# Altium schematic sheet Schematic - V2.SchDoc
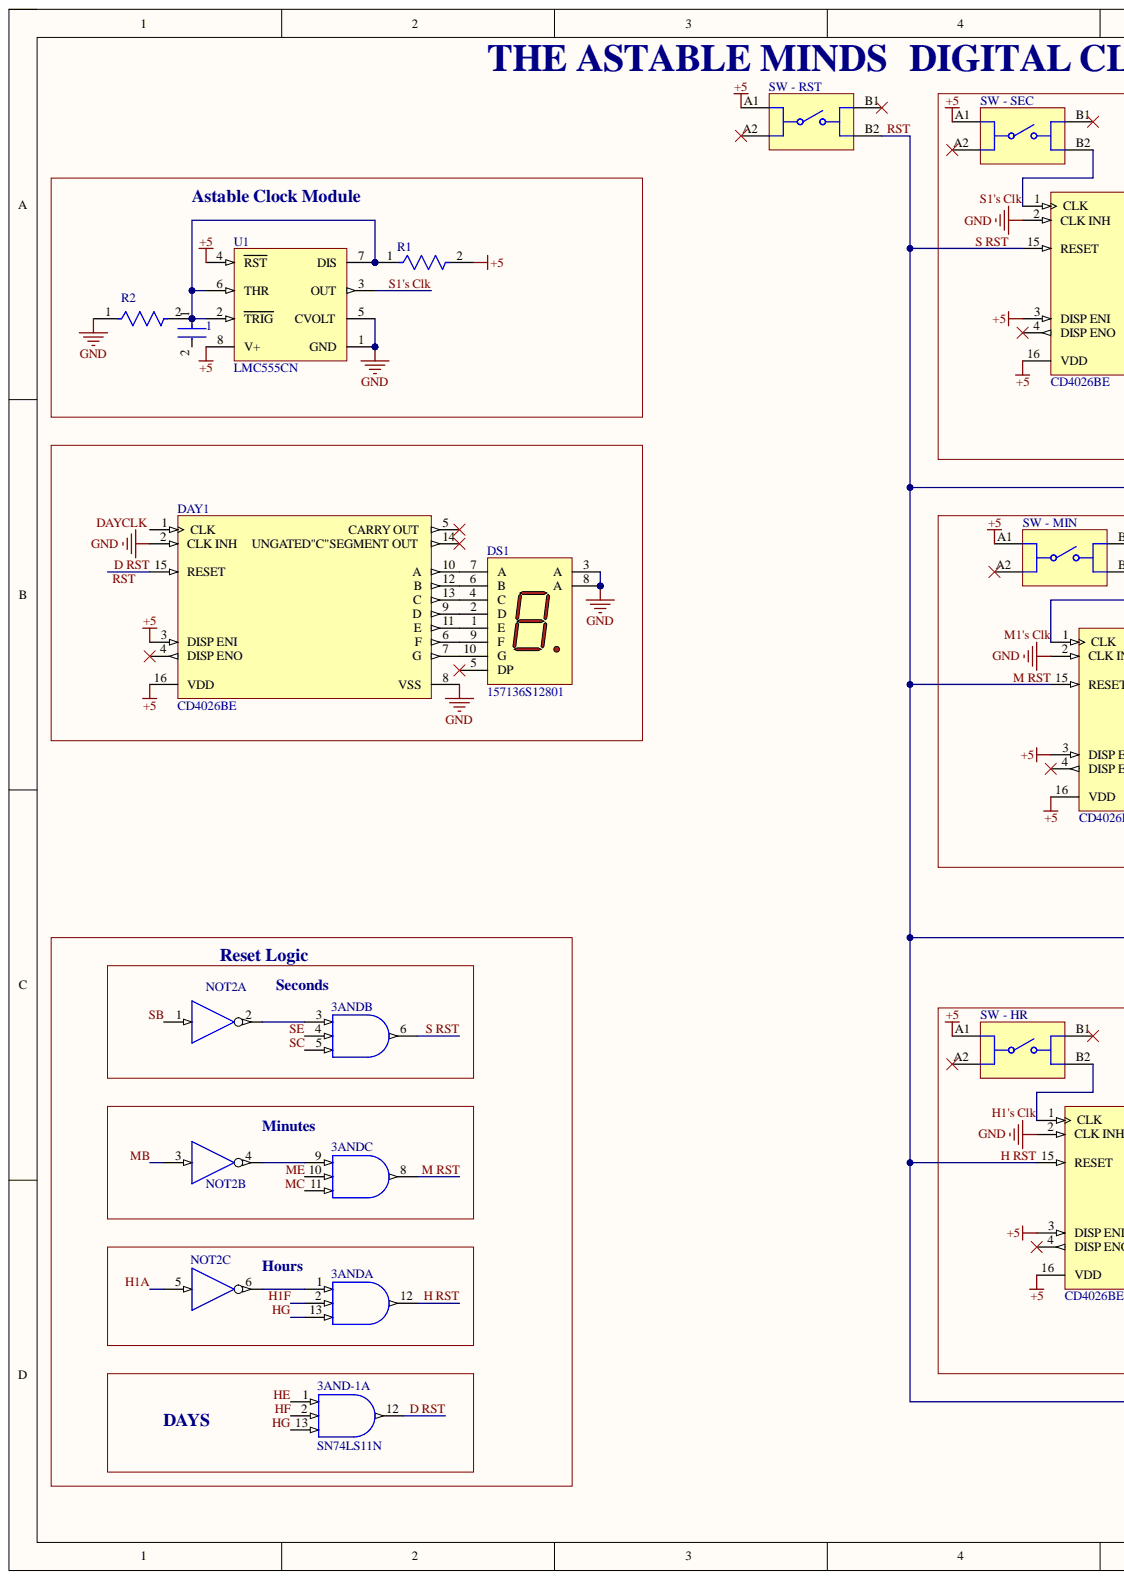
[diagram p0: Schematic - V2.SchDoc, left]
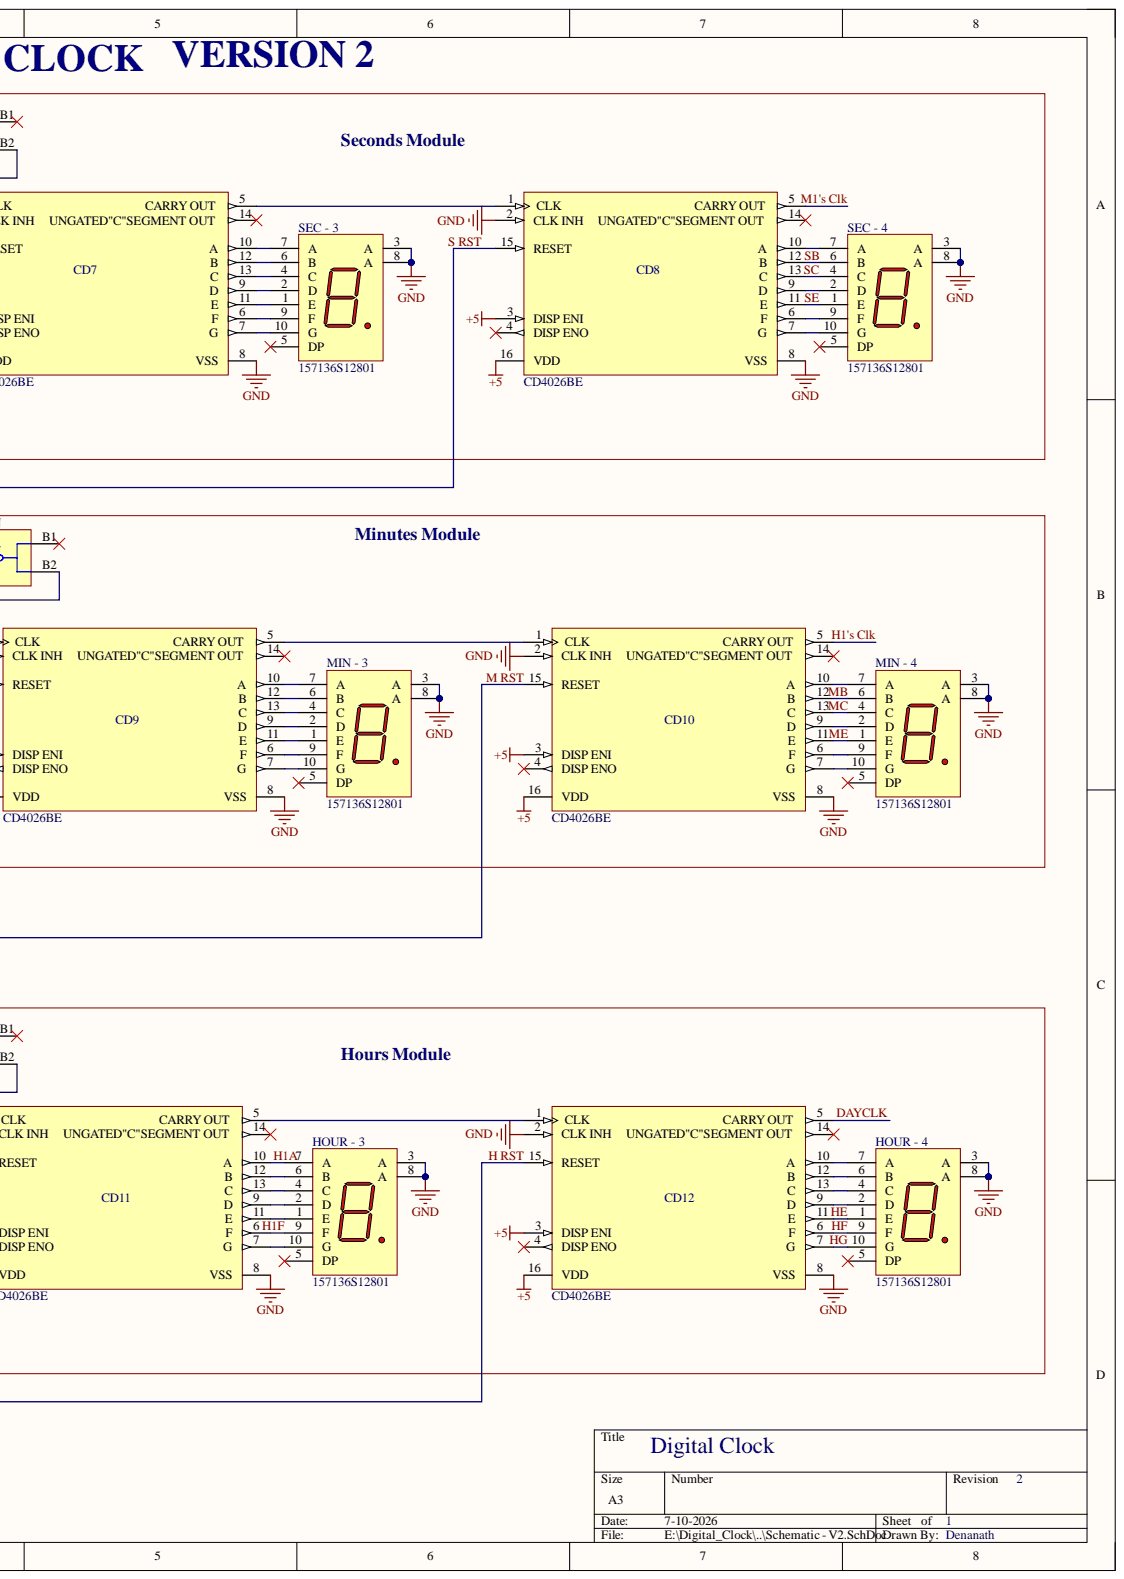
[diagram p1: Schematic - V2.SchDoc, right]

## Parts
1  VY1472M63Y5UQ6TV0  [FP-VY1472M63Y5UQ6TV0-MFG]  (value not drawn)
3AND  SN74LS11N  [N0014A]  (parts A,B,C)
3AND-1  SN74LS11N  [N0014A]  (part A)
CD7  CD4026BE  [N0016A]
CD8  CD4026BE  [N0016A]
CD9  CD4026BE  [N0016A]
CD10  CD4026BE  [N0016A]
CD11  CD4026BE  [N0016A]
CD12  CD4026BE  [N0016A]
DAY1  CD4026BE  [N0016A]
DS1  157136S12801  [WL-T7DS_L17.5W12.4H7]
HOUR_-_3  157136S12801  [WL-T7DS_L17.5W12.4H7]
HOUR_-_4  157136S12801  [WL-T7DS_L17.5W12.4H7]
MIN_-_3  157136S12801  [WL-T7DS_L17.5W12.4H7]
MIN_-_4  157136S12801  [WL-T7DS_L17.5W12.4H7]
NOT2  SN74HCU04N  [N0014A]  (value not drawn)  (parts A,B,C)
R1  VR37000001204JA100  [FP-VR37-MFG]  (value not drawn)
R2  VR37000001204JA100  [FP-VR37-MFG]  (value not drawn)
SEC_-_3  157136S12801  [WL-T7DS_L17.5W12.4H7]
SEC_-_4  157136S12801  [WL-T7DS_L17.5W12.4H7]
SW_-_HR  430186050716  [430186050716]  (value not drawn)
SW_-_MIN  430186050716  [430186050716]  (value not drawn)
SW_-_RST  430186050716  [430186050716]  (value not drawn)
SW_-_SEC  430186050716  [430186050716]  (value not drawn)
U1  LMC555CN  [P0008A]

## Nets
+5: CD7.3(DISP_ENI) CD7.16(VDD) CD8.3(DISP_ENI) CD8.16(VDD) CD9.3(DISP_ENI) CD9.16(VDD) CD10.3(DISP_ENI) CD10.16(VDD) CD11.3(DISP_ENI) CD11.16(VDD) CD12.3(DISP_ENI) CD12.16(VDD) DAY1.3(DISP_ENI) DAY1.16(VDD) R1.2 SW_-_HR.A1 SW_-_MIN.A1 SW_-_RST.A1 SW_-_SEC.A1 U1.4(RST) U1.8(V+)
GND: CD7.2(CLK_INH) CD7.8(VSS) CD8.2(CLK_INH) CD8.8(VSS) CD9.2(CLK_INH) CD9.8(VSS) CD10.2(CLK_INH) CD10.8(VSS) CD11.2(CLK_INH) CD11.8(VSS) CD12.2(CLK_INH) CD12.8(VSS) DAY1.2(CLK_INH) DAY1.8(VSS) DS1.3(A) DS1.8(A) HOUR_-_3.3(A) HOUR_-_3.8(A) HOUR_-_4.3(A) HOUR_-_4.8(A) MIN_-_3.3(A) MIN_-_3.8(A) MIN_-_4.3(A) MIN_-_4.8(A) R2.1 SEC_-_3.3(A) SEC_-_3.8(A) SEC_-_4.3(A) SEC_-_4.8(A) U1.1(GND) U1.5(CVOLT)
D RST: 3AND-1.12 DAY1.15(RESET)
DAYCLK: CD12.5(CARRY_OUT) DAY1.1(CLK)
H1's Clk: CD10.5(CARRY_OUT) CD11.1(CLK) SW_-_HR.B2
H1A: NOT2.5
H1F: 3AND.2 CD11.6(F) HOUR_-_3.9(F)
H RST: 3AND.6 3AND.8 3AND.12 CD7.15(RESET) CD8.15(RESET) CD9.15(RESET) CD10.15(RESET) CD11.15(RESET) CD12.15(RESET) SW_-_RST.B2
HE: 3AND-1.1 CD12.11(E) HOUR_-_4.1(E)
HF: 3AND-1.2 CD12.6(F) HOUR_-_4.9(F)
HG: 3AND.13 3AND-1.13 CD12.7(G) HOUR_-_4.10(G)
M1's Clk: CD8.5(CARRY_OUT) CD9.1(CLK) SW_-_MIN.B2
MB: CD10.12(B) MIN_-_4.6(B) NOT2.3
MC: 3AND.11 CD10.13(C) MIN_-_4.4(C)
ME: 3AND.10 CD10.11(E) MIN_-_4.1(E)
S1's Clk: CD7.1(CLK) SW_-_SEC.B2 U1.3(OUT)
SB: CD8.12(B) NOT2.1 SEC_-_4.6(B)
SC: 3AND.5 CD8.13(C) SEC_-_4.4(C)
SE: 3AND.4 CD8.11(E) SEC_-_4.1(E)
Net1_1: 1.1 R1.1 R2.2 U1.2(TRIG) U1.6(THR) U1.7(DIS)
Net1_2: 1.2
Net3AND_1: 3AND.1 NOT2.6
Net3AND_3: 3AND.3 NOT2.2
Net3AND_9: 3AND.9 NOT2.4
NetCD7_5: CD7.5(CARRY_OUT) CD8.1(CLK)
NetCD7_6: CD7.6(F) SEC_-_3.9(F)
NetCD7_7: CD7.7(G) SEC_-_3.10(G)
NetCD7_9: CD7.9(D) SEC_-_3.2(D)
NetCD7_10: CD7.10(A) SEC_-_3.7(A)
NetCD7_11: CD7.11(E) SEC_-_3.1(E)
NetCD7_12: CD7.12(B) SEC_-_3.6(B)
NetCD7_13: CD7.13(C) SEC_-_3.4(C)
NetCD8_6: CD8.6(F) SEC_-_4.9(F)
NetCD8_7: CD8.7(G) SEC_-_4.10(G)
NetCD8_9: CD8.9(D) SEC_-_4.2(D)
NetCD8_10: CD8.10(A) SEC_-_4.7(A)
NetCD9_5: CD9.5(CARRY_OUT) CD10.1(CLK)
NetCD9_6: CD9.6(F) MIN_-_3.9(F)
NetCD9_7: CD9.7(G) MIN_-_3.10(G)
NetCD9_9: CD9.9(D) MIN_-_3.2(D)
NetCD9_10: CD9.10(A) MIN_-_3.7(A)
NetCD9_11: CD9.11(E) MIN_-_3.1(E)
NetCD9_12: CD9.12(B) MIN_-_3.6(B)
NetCD9_13: CD9.13(C) MIN_-_3.4(C)
NetCD10_6: CD10.6(F) MIN_-_4.9(F)
NetCD10_7: CD10.7(G) MIN_-_4.10(G)
NetCD10_9: CD10.9(D) MIN_-_4.2(D)
NetCD10_10: CD10.10(A) MIN_-_4.7(A)
NetCD11_5: CD11.5(CARRY_OUT) CD12.1(CLK)
NetCD11_7: CD11.7(G) HOUR_-_3.10(G)
NetCD11_9: CD11.9(D) HOUR_-_3.2(D)
NetCD11_10: CD11.10(A) HOUR_-_3.7(A)
NetCD11_11: CD11.11(E) HOUR_-_3.1(E)
NetCD11_12: CD11.12(B) HOUR_-_3.6(B)
NetCD11_13: CD11.13(C) HOUR_-_3.4(C)
NetCD12_9: CD12.9(D) HOUR_-_4.2(D)
NetCD12_10: CD12.10(A) HOUR_-_4.7(A)
NetCD12_12: CD12.12(B) HOUR_-_4.6(B)
NetCD12_13: CD12.13(C) HOUR_-_4.4(C)
NetDAY1_6: DAY1.6(F) DS1.9(F)
NetDAY1_7: DAY1.7(G) DS1.10(G)
NetDAY1_9: DAY1.9(D) DS1.2(D)
NetDAY1_10: DAY1.10(A) DS1.7(A)
NetDAY1_11: DAY1.11(E) DS1.1(E)
NetDAY1_12: DAY1.12(B) DS1.6(B)
NetDAY1_13: DAY1.13(C) DS1.4(C)

Not connected: CD7 4(DISP_ENO) 14(UNGATED"C"SEGMENT_OUT); CD8 4(DISP_ENO) 14(UNGATED"C"SEGMENT_OUT); CD9 4(DISP_ENO) 14(UNGATED"C"SEGMENT_OUT); CD10 4(DISP_ENO) 14(UNGATED"C"SEGMENT_OUT); CD11 4(DISP_ENO) 14(UNGATED"C"SEGMENT_OUT); CD12 4(DISP_ENO) 14(UNGATED"C"SEGMENT_OUT); DAY1 4(DISP_ENO) 5(CARRY_OUT) 14(UNGATED"C"SEGMENT_OUT); DS1 5(DP); HOUR_-_3 5(DP); HOUR_-_4 5(DP); MIN_-_3 5(DP); MIN_-_4 5(DP); SEC_-_3 5(DP); SEC_-_4 5(DP); SW_-_HR A2 B1; SW_-_MIN A2 B1; SW_-_RST A2 B1; SW_-_SEC A2 B1

## Library data of the parts
1 = CMP-02417-000050-1: CAP CER 4700PF 760VAC Y5U RADIAL; Capacitance: 4.7nF; Lead Diameter: 0.6mm; Lead/Base Style: Kinked; Tolerance: 20%; Voltage: 500V; Case/Package: Radial; Component Kind: Standard; Construction: RADIAL; Contact Plating: Tin; Depth: 5mm; Diameter: 16mm; Dielectric: Y5U; Height: 19mm; Height - Seated (Max): 0.787inch; Lead Pitch: 10mm; Library Name: Altium Content Vault; Max Operating Temperature: 125°C; Min Operating Temperature: -40°C; Mount: Through Hole; Package Quantity: 500; Packaging: Tape and Reel; Radiation Hardening: No; Resistor Type: Ceramic; RoHS Compliant: Yes; Series: VY1; Termination: Radial; Thickness: 5mm; Voltage Rating (AC): 500V; Voltage Rating (DC): 500V
3AND 3AND-1 = CMP-0777-00254-3: Triple 3-input positive-AND gates, N0014A, TUBE; Manufacturer: Texas Instruments; Bits(#): 3; F @ Nom Voltage(Max)(Mhz): 35; ICC @ Nom Voltage(Max)(mA): 0.0036; Input Type: TTL; Output Drive (IOL/IOH)(Max)(mA): 0/8; Rating: Catalog; Schmitt Trigger: No; Technology Family: LS; tpd @ Nom Voltage(Max)(ns): 15; VCC(Max)(V): 5.25; VCC(Min)(V): 4.75; Voltage(Nom)(V): 5; Component Kind: Standard; DatasheetVersion: SDLS131; Generic Part Number: SN74LS11; Library Name: Altium Content Vault; Operating Temperature Range(C): 0 to 70; Output Type: TTL; PackageReference: N0014A
CD7-CD12 DAY1 = CMP-1623-00561-2: CMOS Decade Counter/Divider with Decoded 7-Segment Display Outputs and Display Enable, N0016A, TUBE; Manufacturer: Texas Instruments; Bits(#): 7; F @ Nom Voltage(Max)(Mhz): 8; ICC @ Nom Voltage(Max)(mA): 0.03; Inputs: 1; Output Drive (IOL/IOH)(Max)(mA): 1.5/-1.5; Rating: Catalog; Schmitt Trigger: No; Technology Family: CD4000; tpd @ Nom Voltage(Max)(ns): 250; VCC(Max)(V): 18; VCC(Min)(V): 3; Voltage(Nom)(V): 5,10,15; Code_JEDEC: MS-001; Component Kind: Standard; DatasheetVersion: SCHS031B; Function: Counter; Generic Part Number: CD4026B; Input Type: CMOS; Library Name: Altium Content Vault; Operating Temperature Range(C): -55 to 125; Output Type: CMOS; PackageReference: N0016A; Type: Decade
DS1 HOUR_-_3 HOUR_-_4 MIN_-_3 MIN_-_4 SEC_-_3 SEC_-_4 = CMP-03913-119893999-3: 7-Seg Display, Comm Anode, Super Red Rohs Compliant: Yes; Dominant Wavelength: 635nm; Forward Current: 100mA; Forward Voltage: 2V; Luminous Intensity: 20mcd; Max Reverse Voltage (DC): 5V; Component Kind: Standard; Height: 7mm; Length: 17.5mm; Library Name: Altium Content Vault; Max Operating Temperature: 85°C; Min Operating Temperature: -40°C; RoHS: Compliant; Width: 12.4mm
NOT2 = CMP-1615-00910-2: Hex Inverters, N0014A, TUBE; Manufacturer: Texas Instruments; Bits(#): 6; F @ Nom Voltage(Max)(Mhz): 20; ICC @ Nom Voltage(Max)(mA): 0.02; Input Type: CMOS; Output Drive (IOL/IOH)(Max)(mA): -4/4; Rating: Catalog; Schmitt Trigger: No; Technology Family: HC; VCC(Min)(V): 2; Voltage(Nom)(V): 5; Code_JEDEC: MS-001; Component Kind: Standard; DatasheetVersion: SCLS079E; Generic Part Number: SN74HCU04; Library Name: Altium Content Vault; Operating Temperature Range(C): -40 to 85; Output Type: CMOS; PackageReference: N0014A; tpd @ Nom Voltage(Max)(ns): 20; VCC(Max)(V): 6
R1 R2 = CMP-02408-000054-1: RES 1.2M OHM 5% 1/2W AXIAL; Power Rating: 500mW; Resistance: 1.2MR; Temperature Coefficient: 200ppm/°C; Voltage Rating: 3.5kV; Case/Package: Conformal; Component Kind: Standard; Construction: Cylindrical; Contact Plating: Tin; Diameter: 4mm; Length: 10mm; Library Name: Altium Content Vault; Max Operating Temperature: 155°C; Military Standard: Not; Min Operating Temperature: -55°C; Mount: Through Hole; Packaging: Ammo Pack; Radiation Hardening: No; Reach SVHC Compliant: Yes; Resistance Tolerance: 5%; Resistor Type: Metal Film; Termination: Axial; Tolerance: 5%; Voltage Rating (DC): 3.5kV
SW_-_HR SW_-_MIN SW_-_RST SW_-_SEC = CMP-1453-00012-5: Switch Tactile Spst-no 0.05A 12V; Actuator Material: Liquid Crystal Polymer, Polymer; Contact Current Rating: 50mA; Illumination Type: Illuminated, Non-Illuminated; Insulation Resistance: 100MΩ; Mechanical Life: 1Mcycles; Switch Function: Off-Mom; Switch Travel: 250µm; Throw Configuration: SPST; Color: Black; Component Kind: Standard; Contact Material: Steel; Contact Plating: Silver; Current Rating: 50mA; Diameter: 3.5mm; Height: 5mm; Length: 6.2mm; Library Name: Altium Content Vault; Max Operating Temperature: 85°C; Min Operating Temperature: -40°C; Mount: Through Hole; Packaging: Bulk; REACH SVHC: No; RoHS: Compliant; Termination: PC Pin; Voltage Rating: 12V; Width: 6.2mm
U1 = CMP-0056-00035-3: CMOS Timer, 8-pin MDIP; Manufacturer: Texas Instruments; CompType: Analog IC; PackageTopMarking: NSUZXYTTE#*LMC*555CN; PowerWise: N; Code_JEDEC: MS-001-BA; Component Kind: Standard; ComponentLink1Description: Datasheet URL; ComponentLink1URL: http://www.ti.com/general/docs/lit/getliterature.tsp?genericPartNumber=LMC555&fileType=pdf; Library Name: Altium Content Vault; PackageDescription: MDIP, .365 x .250 x .130in, 8 Lead, .100in Pitch; PackageReference: P0008A; PinCount: 8; RoHS: N
